# Altium schematic sheet POWER.SchDoc(POWER)
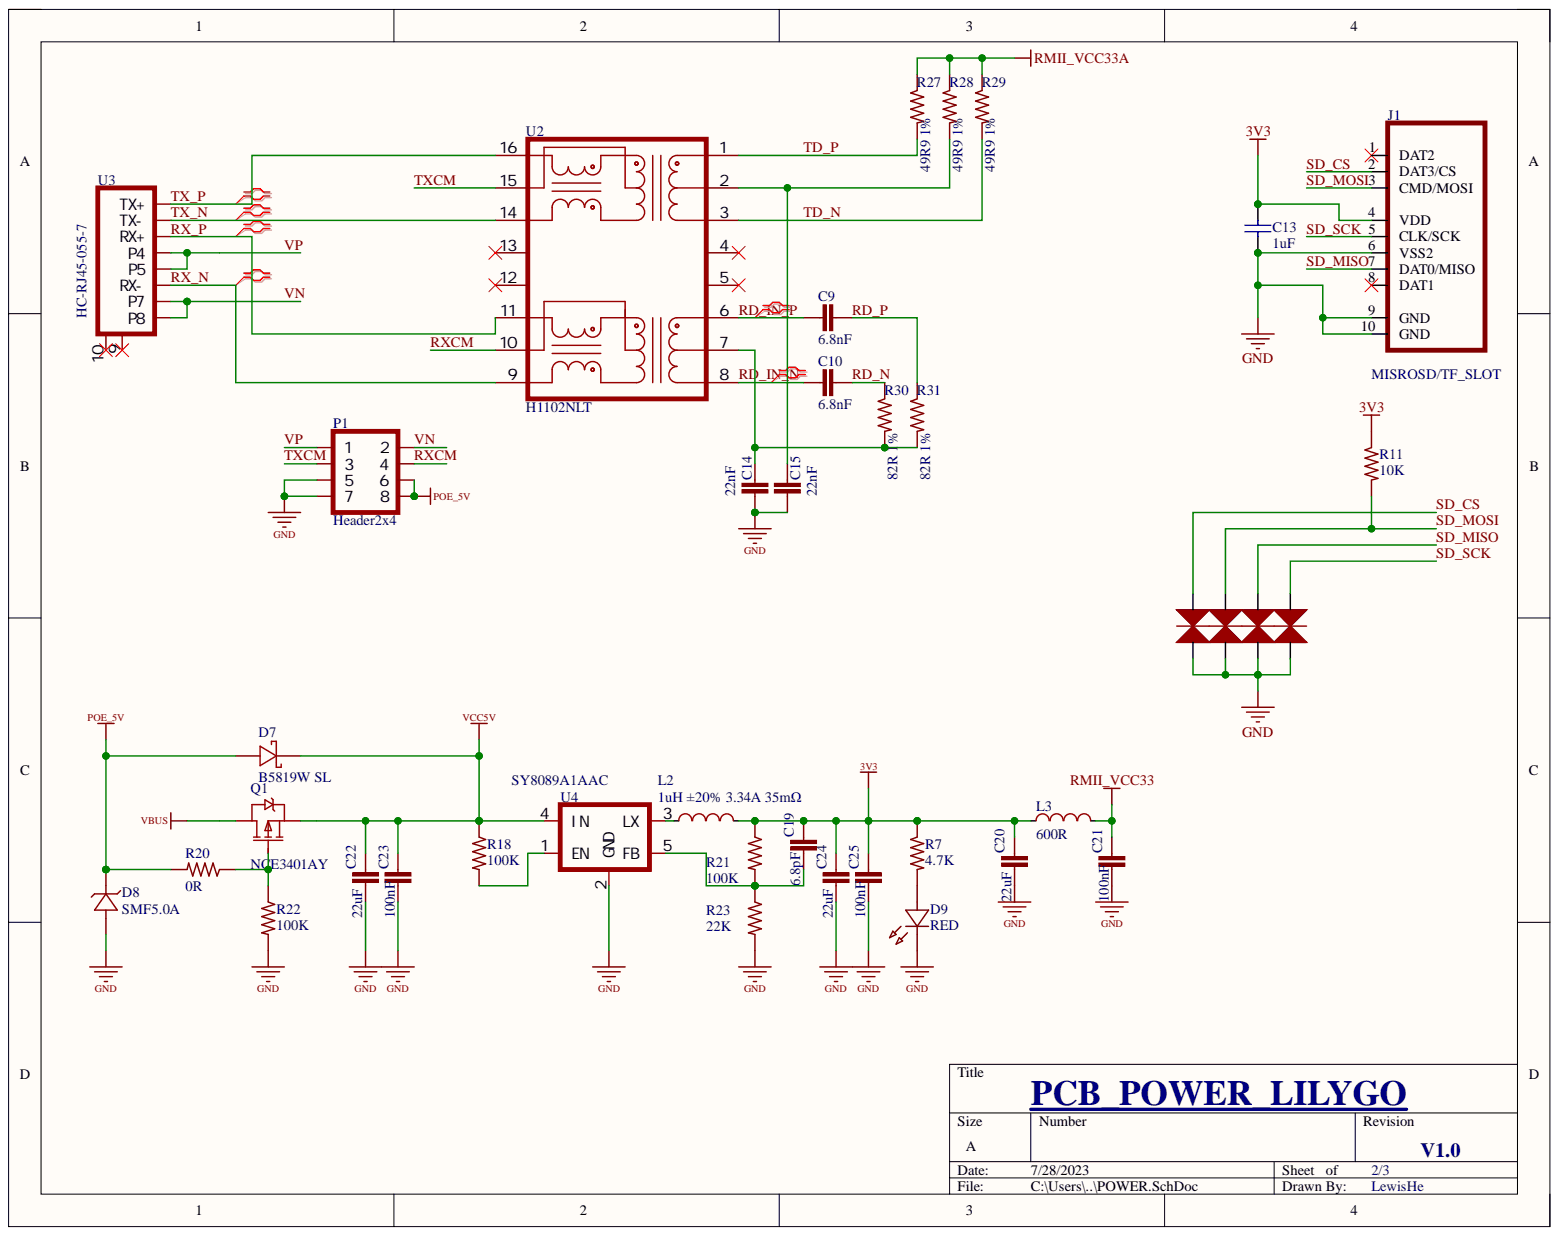
[diagram p0: POWER.SchDoc]

## Parts
C9  6.8nF  [C0402]
C10  6.8nF  [C0402]
C13  1uF  [C0402]
C14  22nF  [c0603]
C15  22nF  [c0603]
C19  6.8pF  [C0402]
C20  22uF  [C0603]
C21  100nF  [C0402]
C22  22uF  [C0603]
C23  100nF  [C0402]
C24  22uF  [C0603]
C25  100nF  [C0402]
D3  ESD05V88D-LC  [DFN-SMD_L1.0-W0.6-BI]  (value not drawn)
D4  ESD05V88D-LC  [DFN-SMD_L1.0-W0.6-BI]  (value not drawn)
D5  ESD05V88D-LC  [DFN-SMD_L1.0-W0.6-BI]  (value not drawn)
D6  ESD05V88D-LC  [DFN-SMD_L1.0-W0.6-BI]  (value not drawn)
D7  B5819W SL  [SOD-123_L2.7-W1.6-LS3.7-RD-1]
D8  SMF5.0A  [SOD-123FL_L2.7-W1.8-LS3.8-RD]
D9  RED  [LED0603_RED]
J1  MISROSD/TF_SLOT  [Micro_SD]
L2  1uH ±20% 3.34A 35mΩ  [IND-SMD_L3.2-W3.0_SPM3012T]
L3  600R  [L0603]
P1  Header2x4  [HDR-TH_8P-P2.54-V-F-R2-C4-S2.54-排针]
Q1  NCE3401AY  [SOT-23-3_L2.9-W1.6-P1.90-LS2.8-BR]
R7  4.7K  [R0402]
R11  10K  [R0402]
R18  100K  [R0402]
R20  0R  [R0402]
R21  100K  [R0402]
R22  100K  [R0402]
R23  22K  [R0402]
R27  49R9 1%  [R0402]
R28  49R9 1%  [R0402]
R29  49R9 1%  [R0402]
R30  82R 1%  [R0402]
R31  82R 1%  [R0402]
U2  H1102NLT  [XFMR-SMD_H1102NLT]
U3  HC-RJ45-055-7  [RJ45-SMD_HC-RJ45-055-7_1]
U4  SY8089A1AAC  [SOT-23-5_L2.9-W1.7-P0.95-LS2.8-BL]

## Nets
3V3: C13.1 C19.2 C20.2 C24.2 C25.2 J1.4(VDD) L2.2 L3.2 R7.1 R11.1 R21.1
GND: C13.2 C14.1 C15.1 C20.1 C21.1 C22.1 C23.1 C24.1 C25.1 D3.2 D4.2 D5.2 D6.2 D8.2 D9.2 J1.6(VSS2) J1.9(GND) J1.10(GND) P1.5 P1.7 R22.2 R23.2 U4.2(GND)
POE_5V: D7.2 D8.1 P1.6 P1.8 R20.2
RMII_VCC33: C21.2 L3.1
RMII_VCC33A: R27.1 R28.1 R29.1
VBUS: Q1.3
VCC5V: C22.2 C23.2 D7.1 Q1.2 R18.1 U4.4(IN)
RD_IN_N: C10.2 U2.8
RD_IN_P: C9.2 U2.6
RD_N: C10.1 R30.1
RD_P: C9.1 R31.1
RX_N: U2.9 U3.6(RX-)
RX_P: U2.11 U3.3(RX+)
RXCM: P1.4 U2.10
SD_CS: D3.1 J1.2(DAT3/CS)
SD_MISO: D5.1 J1.7(DAT0/MISO)
SD_MOSI: D4.1 J1.3(CMD/MOSI) R11.2
SD_SCK: D6.1 J1.5(CLK/SCK)
TD_N: R29.2 U2.3
TD_P: R27.2 U2.1
TX_N: U2.14 U3.2(TX-)
TX_P: U2.16 U3.1(TX+)
TXCM: P1.3 U2.15
VN: P1.2 U3.7(P7) U3.8(P8)
VP: P1.1 U3.4(P4) U3.5(P5)
NetC14_2: C14.2 R30.2 R31.2 U2.7
NetC15_2: C15.2 R28.2 U2.2
NetC19_1: C19.1 R21.2 R23.1 U4.5(FB)
NetD9_1: D9.1 R7.2
NetL2_1: L2.1 U4.3(LX)
NetQ1_1: Q1.1 R20.1 R22.1
NetR18_2: R18.2 U4.1(EN)

Not connected: J1 1(DAT2) 8(DAT1); U2 4 5 12 13; U3 9 10

## Library data of the parts
C9 C10 C14 C15 C19-C25 = C0201: 0201  贴片电容; Component Kind: Standard; Library Name: 常用.SCHLIB
C13 = Cap: Capacitor; Component Kind: Standard; LatestRevisionDate: 17-Jul-2002; LatestRevisionNote: Re-released for DXP Platform.; Library Name: Miscellaneous Devices.IntLib; PackageDescription: Radial Cap, Thru-Hole; 2 Leads; 0.3 in Pin Spacing; PackageReference: RAD-0.3; Published: 8-Jun-2000; Signal Integrity: Cap; Simulation: CAP; Value: 1uF; Publisher: Altium Limited
D3-D6 = ESD05V88D-LC: 系统型号: YLSMT101670; Component Kind: Standard; Library Name: 常用.SCHLIB; 立创链接: https://item.szlcsc.com/5751019.html
D8 = SMF5.0A: TVS; Component Kind: Standard; Library Name: 常用.SCHLIB; 立创链接: https://item.szlcsc.com/231120.html
D9 = LED0603: Component Kind: Standard; Description: RED; Library Name: 常用.SCHLIB
J1 = MISROSD/TF_SLOT: TF卡短款-2.5/2.8高; 系统型号: YLSMT100809; Component Kind: Standard; Library Name: 常用.SCHLIB
L2 = CD32-1uH: 系统型号: 暂无; Component Kind: Standard; Description: 1uH ±20% 3.34A 35mΩ; Library Name: 常用.SCHLIB; 立创链接: https://item.szlcsc.com/2970820.html
L3 = L0603: Component Kind: Standard; Description: L0603; Library Name: 常用.SCHLIB
Q1 = NCE3401AY: P沟道 30V 4.4A; 系统型号: YLSMT101137; Component Kind: Standard; Library Name: 常用.SCHLIB; 立创链接: https://item.szlcsc.com/181200.html
R7 R11 R18 R20-R23 R27-R31 = R0201: Component Kind: Standard; Library Name: 常用.SCHLIB
U2 = H1102NLT: 百兆网络变压器; Component Kind: Standard; ComponentLink1Description: 立创链接; ComponentLink1URL: https://item.szlcsc.com/19286.html; Library Name: 常用.SCHLIB
U3 = HC-RJ45-055-7: 以太网连接器; Component Kind: Standard; ComponentLink1Description: 立创链接; ComponentLink1URL: https://item.szlcsc.com/3480996.html; Library Name: 常用.SCHLIB
D7 = B5819W SL: Component Kind: Standard; ComponentLink1Description: 立创链接; ComponentLink1URL: https://item.szlcsc.com/9093.html; Library Name: 常用.SCHLIB
P1 = Header2x4: Component Kind: Standard; Description: Header2x4; Library Name: 常用.SCHLIB
U4 = SY8089A1AAC: Component Kind: Standard; Description: SY8089A1AAC; Library Name: 常用.SCHLIB
